# Altium schematic sheet Auxillary_Arduino_uC.SchDoc
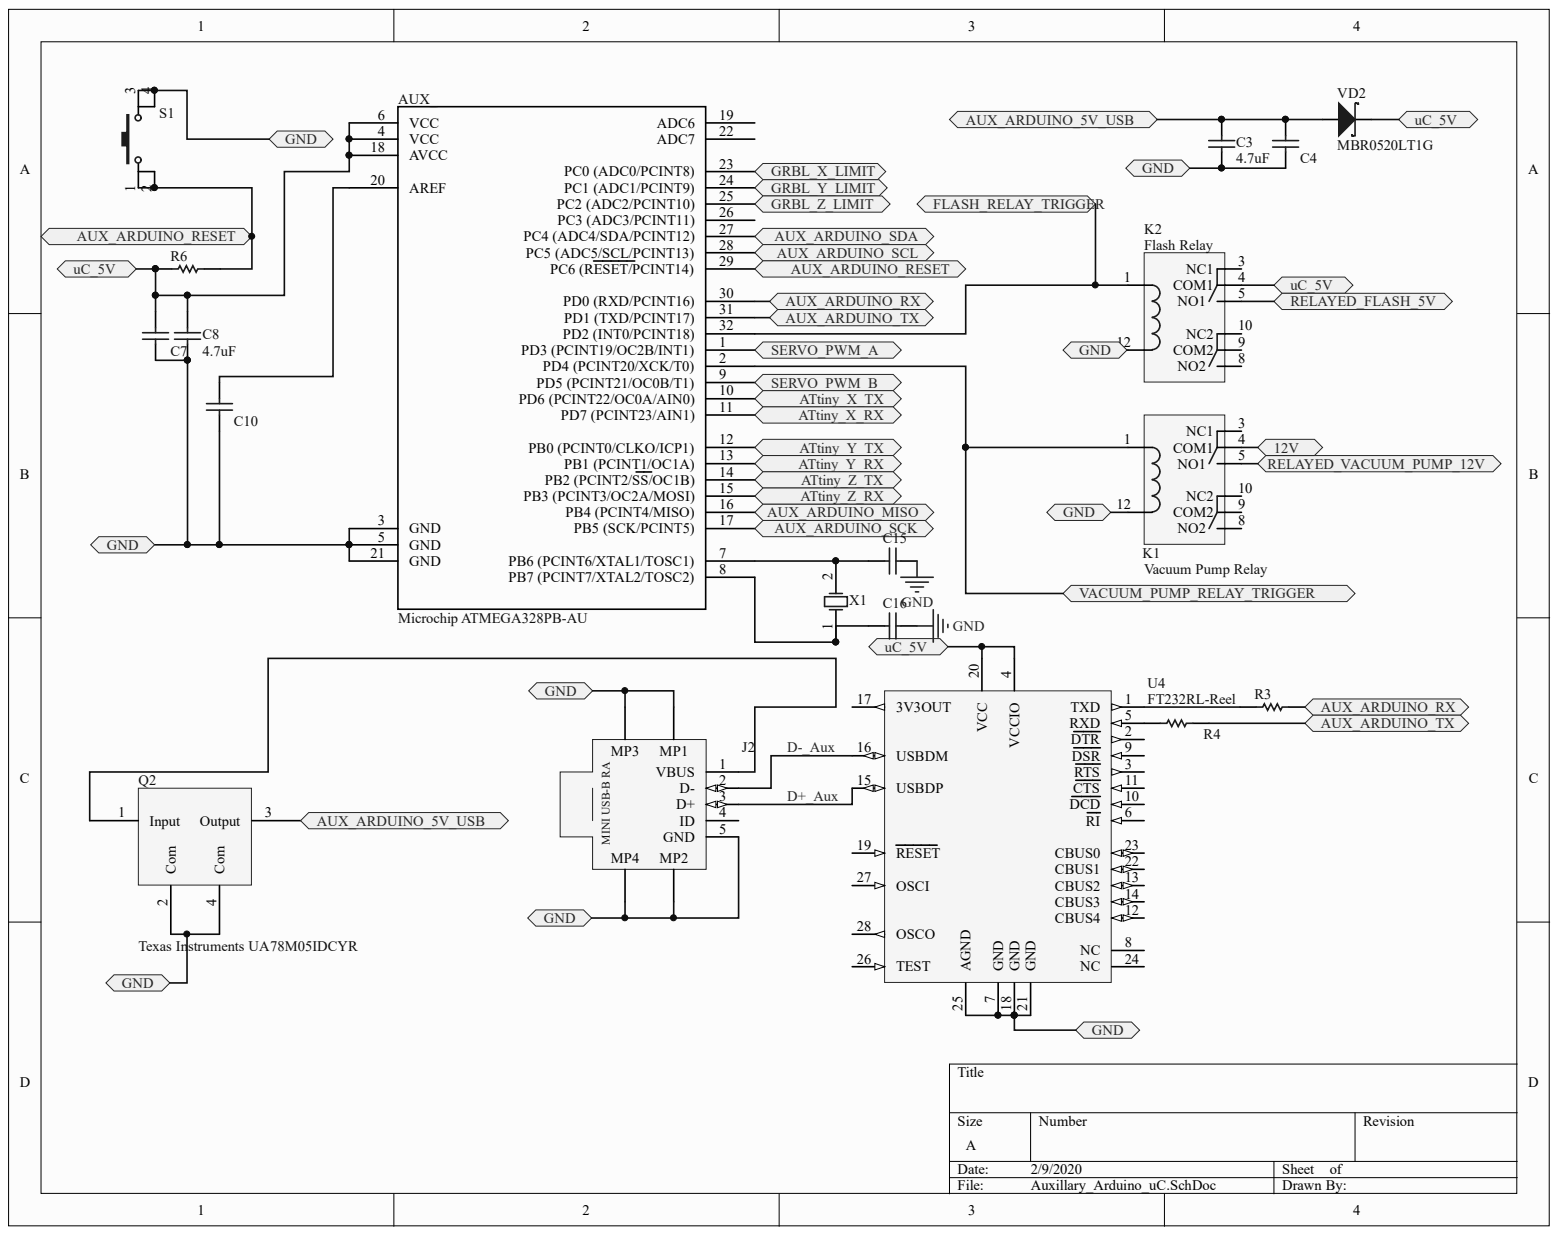
[diagram p0: Auxillary_Arduino_uC.SchDoc]

## Parts
AUX_  Microchip ATMEGA328PB-AU  [PCB-whr5813yqjt9pre9pi4d-1]
C3  4.7uF  [PCB-o44e25ijbg7jfnbnmamr-1]
C4  KEMET C0603C104Z3VACTU  [PCB-2vx2ptnht2odfp520b78-1]  (value not drawn)
C7  KEMET C0603C104Z3VACTU  [PCB-2vx2ptnht2odfp520b78-1]  (value not drawn)
C8  4.7uF  [PCB-o44e25ijbg7jfnbnmamr-1]
C10  KEMET C0603C104Z3VACTU  [PCB-2vx2ptnht2odfp520b78-1]  (value not drawn)
C15  Yageo CC0603JRNPO9BN200  [0603C_B]  (value not drawn)
C16  Yageo CC0603JRNPO9BN200  [0603C_B]  (value not drawn)
J2  USB Mini UJ2-MBH-1-SMT-TR  [USB-MINI-B-RA-5PIN-SMD]  (value not drawn)
K1  Kemet EC2-5NU  [PCB-b1116z3fux0nun8nk7mg-1]  (value not drawn)
K2  Kemet EC2-5NU  [PCB-b1116z3fux0nun8nk7mg-1]  (value not drawn)
Q2  Texas Instruments UA78M05IDCYR  Manufacturer Part Number: UA78M05IDCYR  [PCB-lwczzy0ex47e627hfo4t-1]
R3  RES-2  [SMD0603GRID25PAD90]  (value not drawn)
R4  RES-2  [SMD0603GRID25PAD90]  (value not drawn)
R6  RES-2  [SMD0603GRID25PAD90]  (value not drawn)
S1  TE Connectivity 2-1437565-9  [PCB-jknttd96a2zks7mphmau-1]  (value not drawn)
U4  FT232RL-Reel  [SSOP-28_L]
VD2  MBR0520LT1G  [SOD-123_B]
X1  SYM-2b32e236d469f4f5-1  [PCB-gy4gor98huej9pvydkys-1]  (value not drawn)

## Ports
12V, ATTINY_X_RX, ATTINY_X_TX, ATTINY_Y_RX, ATTINY_Y_TX, ATTINY_Z_RX, ATTINY_Z_TX, AUX_ARDUINO_5V_USB, AUX_ARDUINO_MISO, AUX_ARDUINO_RESET, AUX_ARDUINO_RX, AUX_ARDUINO_SCK, AUX_ARDUINO_SCL, AUX_ARDUINO_SDA, AUX_ARDUINO_TX, FLASH_RELAY_TRIGGER, GND, GRBL_X_LIMIT, GRBL_Y_LIMIT, GRBL_Z_LIMIT, RELAYED_FLASH_5V, RELAYED_VACUUM_PUMP_12V, SERVO_PWM_A, SERVO_PWM_B, UC_5V, VACUUM_PUMP_RELAY_TRIGGER

## Nets
ATTINY_X_RX: AUX_.11(PD7_(PCINT23/AIN1)) ; port ATTINY_X_RX
ATTINY_X_TX: AUX_.10(PD6_(PCINT22/OC0A/AIN0)) ; port ATTINY_X_TX
ATTINY_Y_RX: AUX_.13(PB1_(PCINT1/OC1A)) ; port ATTINY_Y_RX
ATTINY_Y_TX: AUX_.12(PB0_(PCINT0/CLKO/ICP1)) ; port ATTINY_Y_TX
ATTINY_Z_RX: AUX_.15(PB3_(PCINT3/OC2A/MOSI)) ; port ATTINY_Z_RX
ATTINY_Z_TX: AUX_.14(PB2_(PCINT2/SS/OC1B)) ; port ATTINY_Z_TX
UC_5V: AUX_.4(VCC) AUX_.6(VCC) AUX_.18(AVCC) C7.1 C8.1 K2.4(COM1) R6.1 U4.4(VCCIO) U4.20(VCC) VD2.1 ; port UC_5V
12V: K1.4(COM1) ; port 12V
AUX_ARDUINO_5V_USB: C3.1 C4.1 Q2.3(Output) VD2.2 ; port AUX_ARDUINO_5V_USB
AUX_ARDUINO_MISO: AUX_.16(PB4_(PCINT4/MISO)) ; port AUX_ARDUINO_MISO
AUX_ARDUINO_RESET: AUX_.29(PC6_(RESET/PCINT14)) R6.2 S1.1 S1.2 ; port AUX_ARDUINO_RESET
AUX_ARDUINO_RX: AUX_.30(PD0_(RXD/PCINT16)) R3.2 ; port AUX_ARDUINO_RX
AUX_ARDUINO_SCK: AUX_.17(PB5_(SCK/PCINT5)) ; port AUX_ARDUINO_SCK
AUX_ARDUINO_SCL: AUX_.28(PC5_(ADC5/SCL/PCINT13)) ; port AUX_ARDUINO_SCL
AUX_ARDUINO_SDA: AUX_.27(PC4_(ADC4/SDA/PCINT12)) ; port AUX_ARDUINO_SDA
AUX_ARDUINO_TX: AUX_.31(PD1_(TXD/PCINT17)) R4.2 ; port AUX_ARDUINO_TX
D+_AUX: J2.3(D+) U4.15(USBDP)
D-_AUX: J2.2(D-) U4.16(USBDM)
FLASH_RELAY_TRIGGER: AUX_.32(PD2_(INT0/PCINT18)) K2.1 ; port FLASH_RELAY_TRIGGER
GND: AUX_.3(GND) AUX_.5(GND) AUX_.21(GND) C3.2 C4.2 C7.2 C8.2 C10.2 C15.2 C16.2 J2.5(GND) J2.MP1 J2.MP2 J2.MP3 J2.MP4 K1.12 K2.12 Q2.2(Com) Q2.4(Com) S1.3 S1.4 U4.7(GND) U4.18(GND) U4.21(GND) U4.25(AGND) ; port GND
GRBL_X_LIMIT: AUX_.23(PC0_(ADC0/PCINT8)) ; port GRBL_X_LIMIT
GRBL_Y_LIMIT: AUX_.24(PC1_(ADC1/PCINT9)) ; port GRBL_Y_LIMIT
GRBL_Z_LIMIT: AUX_.25(PC2_(ADC2/PCINT10)) ; port GRBL_Z_LIMIT
RELAYED_FLASH_5V: K2.5(NO1) ; port RELAYED_FLASH_5V
RELAYED_VACUUM_PUMP_12V: K1.5(NO1) ; port RELAYED_VACUUM_PUMP_12V
SERVO_PWM_A: AUX_.1(PD3_(PCINT19/OC2B/INT1)) ; port SERVO_PWM_A
SERVO_PWM_B: AUX_.9(PD5_(PCINT21/OC0B/T1)) ; port SERVO_PWM_B
VACUUM_PUMP_RELAY_TRIGGER: AUX_.2(PD4_(PCINT20/XCK/T0)) K1.1 ; port VACUUM_PUMP_RELAY_TRIGGER
NetAUX__7: AUX_.7 C15.1 X1.2
NetAUX__8: AUX_.8(PB7_(PCINT7/XTAL2/TOSC2)) C16.1 X1.1
NetAUX__20: AUX_.20(AREF) C10.1
NetJ2_1: J2.1(VBUS) Q2.1(Input)
NetR3_1: R3.1 U4.1(TXD)
NetR4_1: R4.1 U4.5(RXD)

Not connected: AUX_ 19(ADC6) 22(ADC7) 26(PC3_(ADC3/PCINT11)); J2 4(ID); K1 3(NC1) 8(NO2) 9(COM2) 10(NC2); K2 3(NC1) 8(NO2) 9(COM2) 10(NC2); U4 2(DTR) 3(RTS) 6(RI) 8(NC) 9(DSR) 10(DCD) 11(CTS) 12(CBUS4) 13(CBUS2) 14(CBUS3) 17(3V3OUT) 19(RESET) 22(CBUS1) 23(CBUS0) 24(NC) 26(TEST) 27(OSCI) 28(OSCO)

## Library data of the parts
AUX_ = CMP-216eecc682ecfea9-4: AVR 32KBYTES Flash, 1KBYTES EEPROM, 2KBYTES Ram, W - 20MHZ, TQFP, Ind Temp, Green 5 V; Component Kind: Standard; Library Name: CommunityVault; OctopartID: 216eecc682ecfea9
C3 C8 = CMP-4caf8516eb679368-2: CL10 Series 4.7 uF 10 V ±10 % Tolerance X5R SMT Multilayer Ceramic Capacitor; Manufacturer Part Number: CL10A475KP8NNNC; Capacitance: 4.7e-06 F; Capacitance Tolerance: ±10%; Lead-Free Status: Lead Free; Voltage Rating (DC): 10.0 V; Case/Package: 0603; Component Kind: Standard; Dielectric Characteristic: X5R; Library Name: CommunityVault; Mounting Style: Surface Mount; OctopartID: 4caf8516eb679368; Octopart URL: http://octopart.com/cl10a475kp8nnnc-samsung-11764398; RoHS: Compliant
C4 C7 C10 = CMP-123607-11: Capacitor: ceramic; MLCC; 100nF; 25VDC; Y5V; -20÷+80%; SMD; 0603; Capacitance: 0.1uF; Component Kind: Standard; Library Name: CommunityVault; Manufacturer: KEMET; Manufacturer Part Number: C0603C104Z3VACTU
C15 C16 = CMP-598090fbb8168647-3: 0603 20 pF 50 V ±5 % Tolerance NP0 SMT Multilayer Ceramic Capacitor; Capacitance: 20.0 pF; Capacitance Tolerance: ±5%; Dielectric Characteristic: C0G/NP0; Lead-Free Status: Lead Free; Voltage Rating (DC): 50.0 V; Case/Package: 0603, 1608; Component Kind: Standard; Library Name: CommunityVault; Manufacturer Part Number: CC0603JRNPO9BN200; Mounting Style: Surface Mount; Number of Pins: 2; OctopartID: 598090fbb8168647; Octopart Url: http://octopart.com/cc0603jrnpo9bn200-yageo-7455984; Packaging: Cut Tape (CT); RoHS: Compliant; Seller Landing  Url: https://octopart-clicks.com/click/track?country=US&ak=8157f166&sig=0860d5f&sid=1106&ppid=7455984&vpid=198823968&ct=offers
J2 = CMP-aa1e67dd370375d9-4: USB Connectors USB 2.0 mini B jack 5 pin Horizontal SMT; Manufacturer: CUI; Lead-Free Status: Lead Free; Category: USB Connectors; Component Kind: Standard; Digikey: 102-4003-1-ND; Library Name: CommunityVault; Manufacturer Part Number: UJ2-MBH-1-SMT-TR; OctopartID: aa1e67dd370375d9; Octopart URL: http://octopart.com/uj2-mbh-1-smt-tr-cui-80328827; RoHS: Compliant; Supplier 1: Mouser; Supplier Part Number 1: 490-UJ2-MBH-1-SMT
K1 K2 = CMP-d45a1c8127830b9d-9: Electromechanical Relay 5VDC 178Ohm 2A DPDT (15x7.5x9.4)mm THT Signal Relay; Component Kind: Standard; Library Name: CommunityVault
Q2 = CMP-5a8293807ae38545-1: 500-mA, 25-V linear voltage regulator 4-SOT-223 -40 to 125; Dropout Voltage: 2.00 V; Input Voltage (DC): 25.0 V (max); Number of Regulated Outputs: 1; Output Voltage: 4.75 V (min), 5.00 V (max); Quiescent Current: 4.50 mA; Case/Package: SOT-223; Component Kind: Standard; Library Name: CommunityVault; Lifecycle Status: Active; Mounting Style: Surface Mount; Octopart URL: https://octopart.com/ua78m05idcyr-texas+instruments-525575; Operating Temperature: 125 °C (max); Packaging: Cut Tape (CT), Tape & Reel (TR); REACH SVHC Compliance: No SVHC
R3 R4 R6 = CMP-68a0a2e72c4eb767-17: RC Series 0603 0.1 W 1 kOhms 5% ±100 ppm/°C SMT Thick Film Chip Resistor; Manufacturer Part Number: RC0603JR-071KL; Lead-Free Status: Lead Free; Power Rating: 100 mW; Resistance: 1.00 kΩ; Voltage Rating (DC): 50.0 V; Component Kind: Standard; Library Name: CommunityVault; Mounting Style: Surface Mount; OctopartID: 68a0a2e72c4eb767; Octopart Url: http://octopart.com/rc0603jr-071kl-yageo-55402888; Packaging: Cut Tape (CT), Tape & Reel (TR); REACH SVHC Compliance: No SVHC; Resistance Tolerance: ±5%; RoHS: Compliant; Seller Landing  Url: https://octopart-clicks.com/click/track?country=US&ak=8157f166&sig=04f502c&sid=1106&ppid=55402888&vpid=198667460&ct=offers; Size-Height: 450 µm; Size-Length: 1.60 mm; Size-Width: 800 µm
S1 = CMP-34bcffd9c077eb7b-4: Switch Tactile OFF (ON) SPST Round Button Gull Wing 0.05A 24VDC 1VA 9.18N SMD T/R; Component Kind: Standard; Library Name: CommunityVault
U4 = CMP-0078-00029-4: USB UART Asynchronous Serial Data Transfer Chip, 28-pin SSOP, Tape and Reel; Lead-Free Status: Lead Free; Component Kind: Standard; Library Name: CommunityVault; Manufacturer Part Number: FT232RL-REEL; OctopartID: 4f3d8713e14e90fa; Octopart Url: https://octopart.com/ft232rl-reel-ftdi-19172117; RoHS: Compliant
VD2 = CMP-3786467-10: Rectifier, Schottky Power; Plastic Sod-123; 0.5 a @ +90c If; +125; 20v; 1000; Current Rating: 0.5 A; Forward Voltage: 0.385 V; Lead-Free Status: Lead Free; Polarity: Standard; Voltage Rating (DC): 20.0 V; Case/Package: SOD-123; Component Kind: Standard; Library Name: CommunityVault; Lifecycle Status: Active; Manufacturer Part Number: MBR0520LT1G; Mounting Style: Surface Mount; Number of Pins: 2; OctopartID: 81934899af805e54; Octopart Url: http://octopart.com/mbr0520lt1g-on+semiconductor-2933; REACH SVHC Compliance: No SVHC; RoHS: Compliant; Seller Landing  Url: https://octopart-clicks.com/click/track?country=US&ak=8157f166&sig=02b8313&sid=5489&ppid=2933&vpid=120602749&ct=offers
X1 = CMP-2b32e236d469f4f5-1: Crystal 16MHz; +/-50ppm; 2-Pin HC-49-S; Manufacturer Part Number: AS-16.000-18; Equivalent Series Resistance (ESR): 40.0 Ω; Frequency: 16.0 MHz; Load Capacitance: 18.0 pF; Component Kind: Standard; Library Name: CommunityVault; Mounting Style: Through Hole; Number of Pins: 2; REACH SVHC Compliance: No SVHC; RoHS: Compliant
